# Altium schematic sheet vehicle.SchDoc(vehicle)
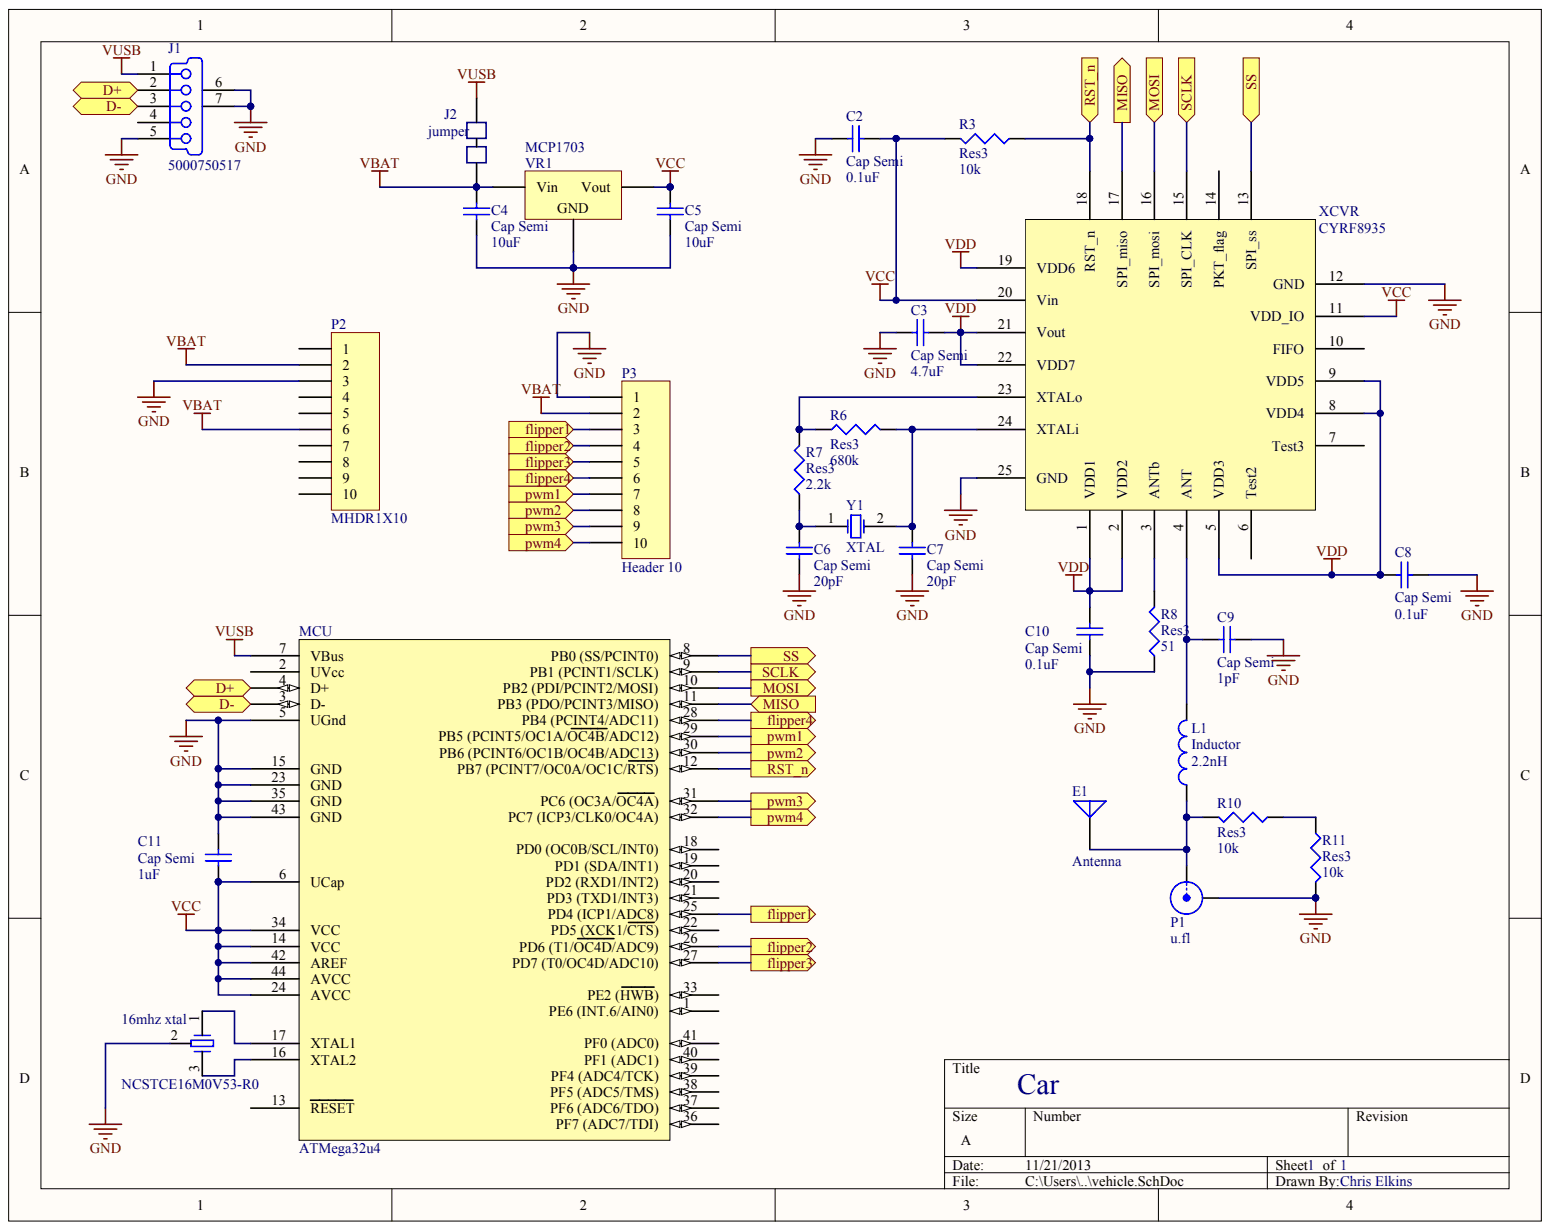
[diagram p0: vehicle.SchDoc]

## Parts
16mhz_xtal  NCSTCE16M0V53-R0
C2  Cap Semi  value 0.1uF
C3  Cap Semi  value 4.7uF
C4  Cap Semi  value 10uF
C5  Cap Semi  value 10uF
C6  Cap Semi  value 20pF
C7  Cap Semi  value 20pF
C8  Cap Semi  value 0.1uF
C9  Cap Semi  value 1pF
C10  Cap Semi  value 0.1uF
C11  Cap Semi  value 1uF
E1  Antenna
J1  5000750517
J2  jumper
L1  Inductor  value 2.2nH
MCU  ATMega32u4
P1  u.fl
P2  MHDR1X10
P3  Header 10
R3  Res3  value 10k
R6  Res3  value 680k
R7  Res3  value 2.2k
R8  Res3  value 51
R10  Res3  value 10k
R11  Res3  value 10k
VR1  MCP1703
XCVR  CYRF8935
Y1  XTAL

## Ports
D+, D-, FLIPPER1, FLIPPER2, FLIPPER3, FLIPPER4, MISO, MOSI, PWM1, PWM2, PWM3, PWM4, RST_N, SCLK, SS

## Nets
GND: 16mhz_xtal.2 C2.1 C3.1 C4.1 C5.1 C6.1 C7.1 C8.1 C9.2 C10.2 C11.2 J1.5 J1.6 J1.7 MCU.5(UGnd) MCU.15(GND) MCU.23(GND) MCU.35(GND) MCU.43(GND) P1.2 P2.3 P3.1 R8.1 R11.2 VR1.2 XCVR.12(GND) XCVR.25(GND)
VBAT: C4.2 J2.2 P2.2 P2.6 P3.2 VR1.1
VCC: C2.2 C5.2 C11.1 MCU.6(UCap) MCU.14(VCC) MCU.24(AVCC) MCU.34(VCC) MCU.42(AREF) MCU.44(AVCC) R3.2 VR1.3 XCVR.11(VDD_IO) XCVR.20(Vin)
VDD: C3.2 C8.2 C10.1 XCVR.1(VDD1) XCVR.2(VDD2) XCVR.5(VDD3) XCVR.8(VDD4) XCVR.9(VDD5) XCVR.19(VDD6) XCVR.21(Vout) XCVR.22(VDD7)
VUSB: J1.1 J2.1 MCU.7(VBus)
MISO: MCU.11(PB3_(PDO/PCINT3/MISO)) XCVR.17(SPI_miso) ; port MISO
MOSI: MCU.10(PB2_(PDI/PCINT2/MOSI)) XCVR.16(SPI_mosi) ; port MOSI
SCLK: MCU.9(PB1_(PCINT1/SCLK)) XCVR.15(SPI_CLK) ; port SCLK
SS: MCU.8(PB0_(SS/PCINT0)) XCVR.13(SPI_ss) ; port SS
Net16mhz xtal_1: 16mhz_xtal.1 MCU.17(XTAL1)
Net16mhz xtal_3: 16mhz_xtal.3 MCU.16(XTAL2)
NetC6_2: C6.2 R7.1 Y1.1
NetC7_2: C7.2 R6.2 XCVR.24(XTALi) Y1.2
NetC9_1: C9.1 L1.2 XCVR.4(ANT)
NetE1_1: E1.1 L1.1 P1.1 R10.2
NetJ1_2: J1.2 MCU.4(D+)
NetJ1_3: J1.3 MCU.3(D-)
NetMCU_12: MCU.12 R3.1 XCVR.18(RST_n) ; port RST_N
NetMCU_25: MCU.25(PD4_(ICP1/ADC8)) P3.3 ; port FLIPPER1
NetMCU_26: MCU.26(PD6_(T1/OC4D/ADC9)) P3.4 ; port FLIPPER2
NetMCU_27: MCU.27(PD7_(T0/OC4D/ADC10)) P3.5 ; port FLIPPER3
NetMCU_28: MCU.28(PB4_(PCINT4/ADC11)) P3.6 ; port FLIPPER4
NetMCU_29: MCU.29(PB5_(PCINT5/OC1A/OC4B/ADC12)) P3.7 ; port PWM1
NetMCU_30: MCU.30(PB6_(PCINT6/OC1B/OC4B/ADC13)) P3.8 ; port PWM2
NetMCU_31: MCU.31(PC6_(OC3A/OC4A)) P3.9 ; port PWM3
NetMCU_32: MCU.32(PC7_(ICP3/CLK0/OC4A)) P3.10 ; port PWM4
NetR6_1: R6.1 R7.2 XCVR.23(XTALo)
NetR8_2: R8.2 XCVR.3(ANTb)
NetR10_1: R10.1 R11.1

Not connected: J1 4; MCU 1(PE6_(INT.6/AIN0)) 2(UVcc) 13(RESET) 18(PD0_(OC0B/SCL/INT0)) 19(PD1_(SDA/INT1)) 20(PD2_(RXD1/INT2)) 21(PD3_(TXD1/INT3)) 22(PD5_(XCK1/CTS)) 33(PE2_(HWB)) 36(PF7_(ADC7/TDI)) 37(PF6_(ADC6/TDO)) 38(PF5_(ADC5/TMS)) 39(PF4_(ADC4/TCK)) 40(PF1_(ADC1)) 41(PF0_(ADC0)); P2 1 4 5 7 8 9 10; XCVR 6(Test2) 7(Test3) 10(FIFO) 14(PKT_flag)

## Library data of the parts
J1 = (no symbol name): PCB_Layout: Complies with Manufacturer's Recommendation.; Published: 30-May-2008; DatasheetVersion: rev0, Mar-2004; PackageVersion: rev0, Mar-2004; PackageReference: 5000750517; PackageDescription: USB Mini-B, Type 2, Thru-Hole; 5 Position; Vertical, Body 7.7 x 4.2 x 7.7 mm (LxWxH); ComponentLink1Description: Manufacturer Link; ComponentLink1URL: http://www.molex.com/; ComponentLink2Description: Datasheet; ComponentLink2URL: http://www.molex.com/pdm_docs/sd/5000750517_sd.pdf; Publisher: Altium Limited
L1 = (no symbol name): Code_IEC: 1005; Published: 8-Jun-2000; LatestRevisionDate: 29-May-2009; LatestRevisionNote: IPC-7351 Footprint Added.; PackageReference: 0402-A; PackageDescription: Chip Inductor; Code_JEITA: 0402; Publisher: Altium Limited
R3 R10 = (no symbol name): Value: 10k; Published: 8-Jun-2000; LatestRevisionDate: 29-May-2009; LatestRevisionNote: IPC-7351 Footprint Added.; PackageReference: J1-0603; PackageDescription: Chip Resistor; Publisher: Altium Limited
R6 = (no symbol name): Value: 680k; Published: 8-Jun-2000; LatestRevisionDate: 29-May-2009; LatestRevisionNote: IPC-7351 Footprint Added.; PackageReference: J1-0603; PackageDescription: Chip Resistor; Publisher: Altium Limited
16mhz_xtal C2-C11 E1 J2 MCU P1-P3 R7 R8 R11 VR1 XCVR Y1 = (no symbol name)
  C2-C11: Published: 8-Jun-2000; LatestRevisionDate: 29-May-2009; LatestRevisionNote: IPC-7351 Footprint Added.; PackageReference: C1206; PackageDescription: Chip Capacitor
  E1: Published: 24-Mar-1999; LatestRevisionDate: 17-Jul-2002; LatestRevisionNote: Re-released for DXP Platform.; PackageReference: PIN1
  P1-P3: LatestRevisionDate: 17-Jul-2002; LatestRevisionNote: Re-released for DXP Platform.
  R7 R8 R11: Published: 8-Jun-2000; LatestRevisionDate: 29-May-2009; LatestRevisionNote: IPC-7351 Footprint Added.; PackageReference: J1-0603; PackageDescription: Chip Resistor
  VR1: Published: 24-Mar-1999; LatestRevisionDate: 29-May-2009; LatestRevisionNote: IPC-7351 Footprint Added.; PackageReference: D2PAK; PackageDescription: 3-Pin Transistor Outline Package 2.54 mm Pitch, 15.1 mm Lead Span
  Y1: Published: 8-Jun-2000; LatestRevisionDate: 17-Jul-2002; LatestRevisionNote: Re-released for DXP Platform.; PackageReference: R38
  C2-C11 E1 P1-P3 R7 R8 R11 VR1 Y1: Publisher: Altium Limited
